# Altium schematic sheet joint_board.SchDoc
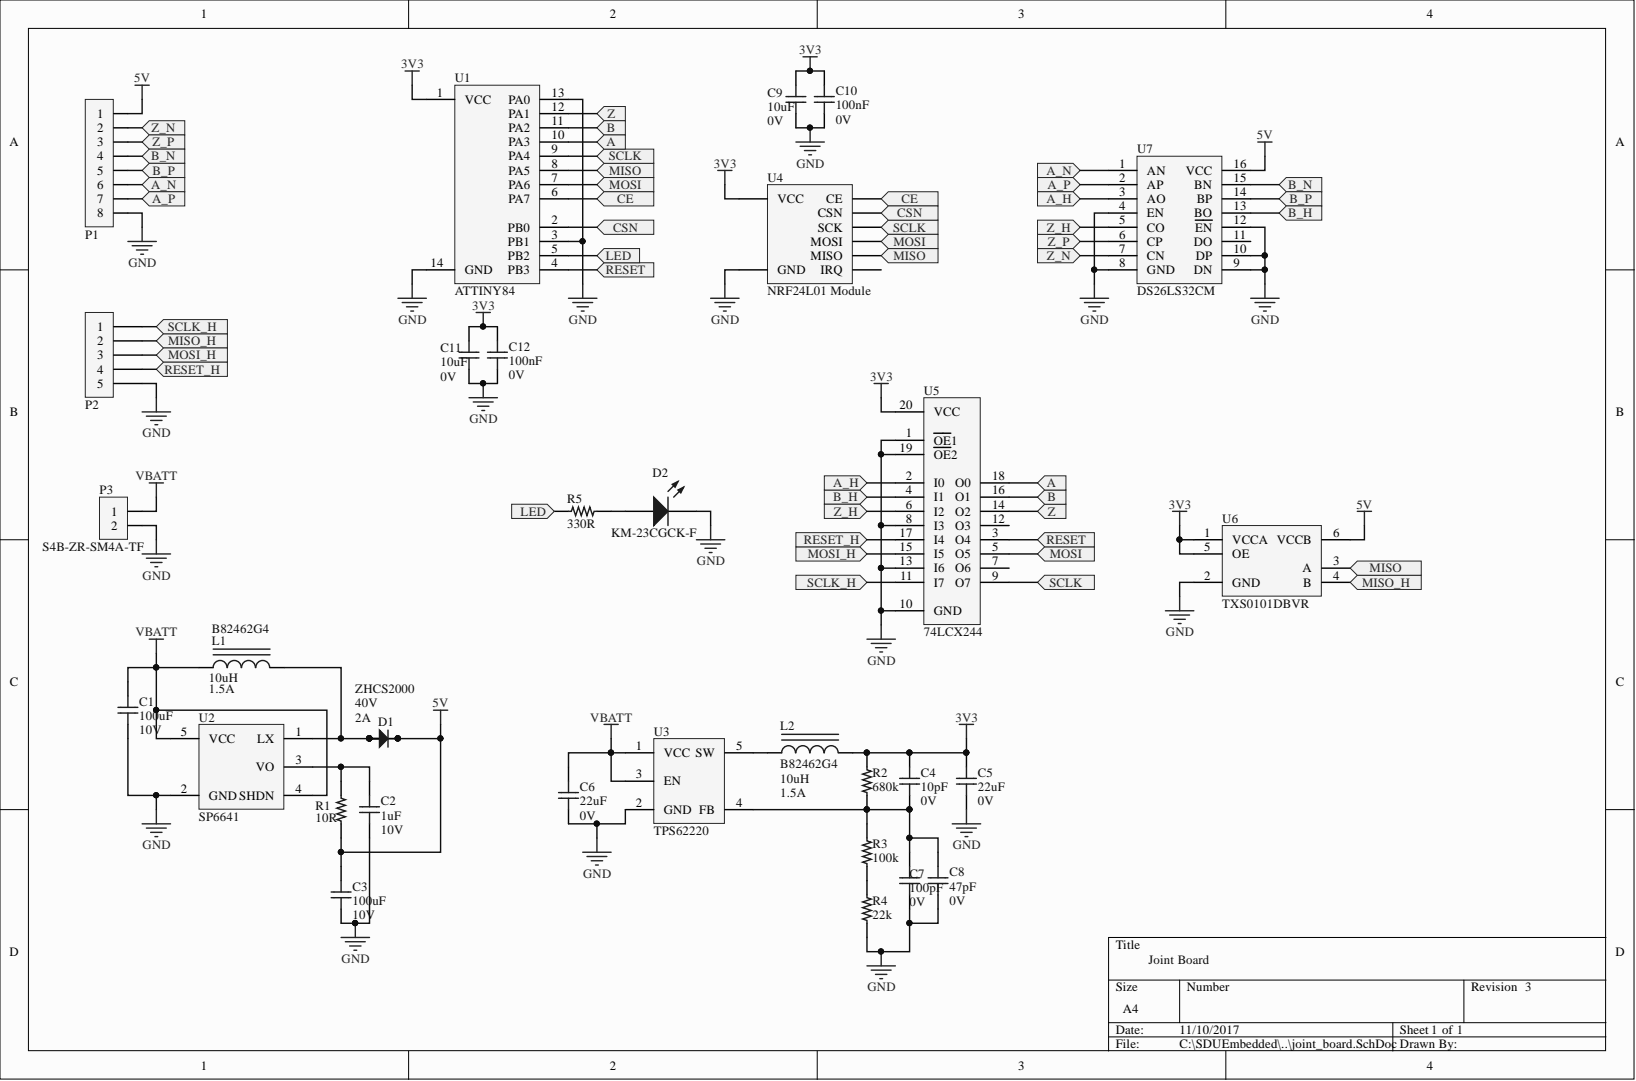
[diagram p0: joint_board.SchDoc]

## Parts
C1  value 100uF  Voltage Rating: 10V  [CAP-0805]  (hidden comment: Capacitor)
C2  value 1uF  Voltage Rating: 10V  [CAP-0805]  (hidden comment: Capacitor)
C3  value 100uF  Voltage Rating: 10V  [CAP-0805]  (hidden comment: Capacitor)
C4  value 10pF  Voltage Rating: 0V  [CAP-0805]  (hidden comment: Capacitor)
C5  value 22uF  Voltage Rating: 0V  [CAP-0805]  (hidden comment: Capacitor)
C6  value 22uF  Voltage Rating: 0V  [CAP-0805]  (hidden comment: Capacitor)
C7  value 100pF  Voltage Rating: 0V  [CAP-0805]  (hidden comment: Capacitor)
C8  value 47pF  Voltage Rating: 0V  [CAP-0805]  (hidden comment: Capacitor)
C9  value 10uF  Voltage Rating: 0V  [CAP-0805]  (hidden comment: Capacitor)
C10  value 100nF  Voltage Rating: 0V  [CAP-0805]  (hidden comment: Capacitor)
C11  value 10uF  Voltage Rating: 0V  [CAP-0805]  (hidden comment: Capacitor)
C12  value 100nF  Voltage Rating: 0V  [CAP-0805]  (hidden comment: Capacitor)
D1  ZHCS2000  Current: 2A  Reverse Voltage: 40V  [SOT23-6]
D2  KM-23CGCK-F  [SOT-23B]
L1  B82462G4  value 10uH  Current: 1.5A  [B82462G4]
L2  B82462G4  value 10uH  [B82462G4]
P1  ZH-8P  [ZH-8P]  (value not drawn)
P2  ZH-5P  [ZH-5P]  (value not drawn)
P3  S4B-ZR-SM4A-TF  [ZH-2P-S]
R1  value 10R  [RES-0805]  (hidden comment: RES-0805)
R2  value 680k  [RES-0805]  (hidden comment: RES-0805)
R3  value 100k  [RES-0805]  (hidden comment: RES-0805)
R4  value 22k  [RES-0805]  (hidden comment: RES-0805)
R5  value 330R  [RES-0805]  (hidden comment: RES-0805)
U1  ATTINY84  [SOIC-14]
U2  SP6641  [SOT23-5]
U3  TPS62220  [SOT23-5]
U4  NRF24L01 Module  [NRF24L01 Module]
U5  74LCX244  [DQFN-20]
U6  TXS0101DBVR  [SOT23-6]
U7  DS26LS32CM  [SOIC-16]

## Ports
A, A_H, A_N, A_P, B, B_H, B_N, B_P, CE, CSN, LED, MISO, MISO_H, MOSI, MOSI_H, RESET, RESET_H, SCLK, SCLK_H, Z, Z_H, Z_N, Z_P

## Nets
3V3: C4.2 C5.2 C9.2 C10.2 C11.2 C12.2 L2.2 R2.2 U1.1(VCC) U4.1(VCC) U5.20(VCC) U6.1(VCCA) U6.5(OE)
5V: C3.2 D1.1 D1.6 P1.1 R1.1 U6.6(VCCB) U7.16(VCC)
GND: C1.1 C2.1 C3.1 C5.1 C6.1 C7.1 C8.1 C9.1 C10.1 C11.1 C12.1 D2.3 P1.8 P2.5 P3.2 R4.1 U1.3(PB1) U1.13(PA0) U1.14(GND) U2.2(GND) U3.2(GND) U4.2(GND) U5.1(OE1) U5.8(I3) U5.10(GND) U5.13(I6) U5.19(OE2) U6.2(GND) U7.4(EN) U7.8(GND) U7.9(DN) U7.10(DP) U7.12(EN)
VBATT: C1.2 C6.2 L1.1 P3.1 U2.4(SHDN) U2.5(VCC) U3.1(VCC) U3.3(EN)
A: U1.10(PA3) U5.18(O0) ; port A
A_H: U5.2(I0) U7.3(AO) ; port A_H
A_N: P1.6 U7.1(AN) ; port A_N
A_P: P1.7 U7.2(AP) ; port A_P
B: U1.11(PA2) U5.16(O1) ; port B
B_H: U5.4(I1) U7.13(BO) ; port B_H
B_N: P1.4 U7.15(BN) ; port B_N
B_P: P1.5 U7.14(BP) ; port B_P
CE: U1.6(PA7) U4.3(CE) ; port CE
CSN: U1.2(PB0) U4.4(CSN) ; port CSN
LED: R5.2 U1.5(PB2) ; port LED
MISO: U1.8(PA5) U4.7(MISO) U6.3(A) ; port MISO
MISO_H: P2.2 U6.4(B) ; port MISO_H
MOSI: U1.7(PA6) U4.6(MOSI) U5.5(O5) ; port MOSI
MOSI_H: P2.3 U5.15(I5) ; port MOSI_H
RESET: U1.4(PB3) U5.3(O4) ; port RESET
RESET_H: P2.4 U5.17(I4) ; port RESET_H
SCLK: U1.9(PA4) U4.5(SCK) U5.9(O7) ; port SCLK
SCLK_H: P2.1 U5.11(I7) ; port SCLK_H
Z: U1.12(PA1) U5.14(O2) ; port Z
Z_H: U5.6(I2) U7.5(CO) ; port Z_H
Z_N: P1.2 U7.7(CN) ; port Z_N
Z_P: P1.3 U7.6(CP) ; port Z_P
NetC2_2: C2.2 R1.2 U2.3(VO)
NetC4_1: C4.1 C7.2 C8.2 R2.1 R3.2 U3.4(FB)
NetD1_2: D1.2 D1.3 D1.4 D1.5 L1.2 U2.1(LX)
NetD2_1: D2.1 R5.1
NetL2_1: L2.1 U3.5(SW)
NetR3_1: R3.1 R4.2

Not connected: U4 8(IRQ); U5 7(O6) 12(O3); U7 11(DO)

## Library data of the parts
C1-C12 = CAP-0805: Component Kind: Standard
  C1-C3: Library Name: SDUEmbedded_Base.SchLib
  C4-C12: Library Name: SDUEmbedded_Base.IntLib
D1 = ZHCS2000: Schottky barrier diode 40V/2A; Component Kind: Standard; Supplier 1: RSComponents; Supplier Part Number 1: 155-175P; Library Name: SDUEmbedded_Base.SchLib
D2 = KM-23CGCK-F: LED Green  2.5V; Component Kind: Standard; Supplier 1: RSComponents; Supplier Part Number 1: 860-8841; Library Name: SDUEmbedded_Base.SchLib
L1 = B82462G4: Epcos inductor 10uH/1.5A; Component Kind: Standard; Supplier 1: RSComponents; Supplier Part Number 1: 4958127; Library Name: SDUEmbedded_Base.SchLib
L2 = B82462G4: Epcos inductor 10uH/1.5A; Current: 1.5A; Component Kind: Standard; Supplier 1: RSComponents; Supplier Part Number 1: 4958127; Library Name: SDUEmbedded_Base.SchLib
P3 = S4B-ZR-SM4A-TF: JST ZH-series 2P connector; Component Kind: Standard; Supplier 1: RSComponents; Supplier Part Number 1: 762-0875; Library Name: SDUEmbedded_Connectors.SchLib
U1 = ATTINY84: Atmel 8-bit Microcontroller; Component Kind: Standard; Library Name: SDUEmbedded_IC.SchLib
U2 = SP6641: DC/DC Boost Regulator 0.5A; Component Kind: Standard; Supplier 1: RSComponents; Supplier Part Number 1: 769-5400P; Library Name: SDUEmbedded_Power.SchLib
U3 = TPS62220: Buck converter 0.7V-6V/400mA; Component Kind: Standard; Supplier 1: RSComponents; Supplier Part Number 1: 147253; Library Name: SDUEmbedded_Power.SchLib
U5 = 74LCX244: 3.3V Buffer; Component Kind: Standard; Supplier 1: RSComponents; Supplier Part Number 1: 803-0894P; Library Name: SDUEmbedded_IC.SchLib
U6 = TXS0101DBVR: Logic-Level shifter dual-supply; Component Kind: Standard; Supplier 1: RSComponents; Supplier Part Number 1: 817-5648P; Library Name: SDUEmbedded_IC.SchLib
U7 = DS26LS32CM: Quad Channel RS422 Receiver; Component Kind: Standard; Supplier 1: RSComponents; Supplier Part Number 1: 856-443; Library Name: SDUEmbedded_IC.SchLib
P1 = ZH-8P: Component Kind: Standard; Library Name: SDUEmbedded_Connectors.SchLib
P2 = ZH-5P: Component Kind: Standard; Library Name: SDUEmbedded_Connectors.SchLib
R1-R5 = RES-0805: Component Kind: Standard
  R1: Library Name: SDUEmbedded_Base.SchLib
  R2-R5: Library Name: SDUEmbedded_Base.IntLib
U4 = NRF24L01 Module: Component Kind: Standard; Library Name: SDUEmbedded.SchLib
